# Altium schematic sheet NRF24L01.SchDoc(NRF24L01)
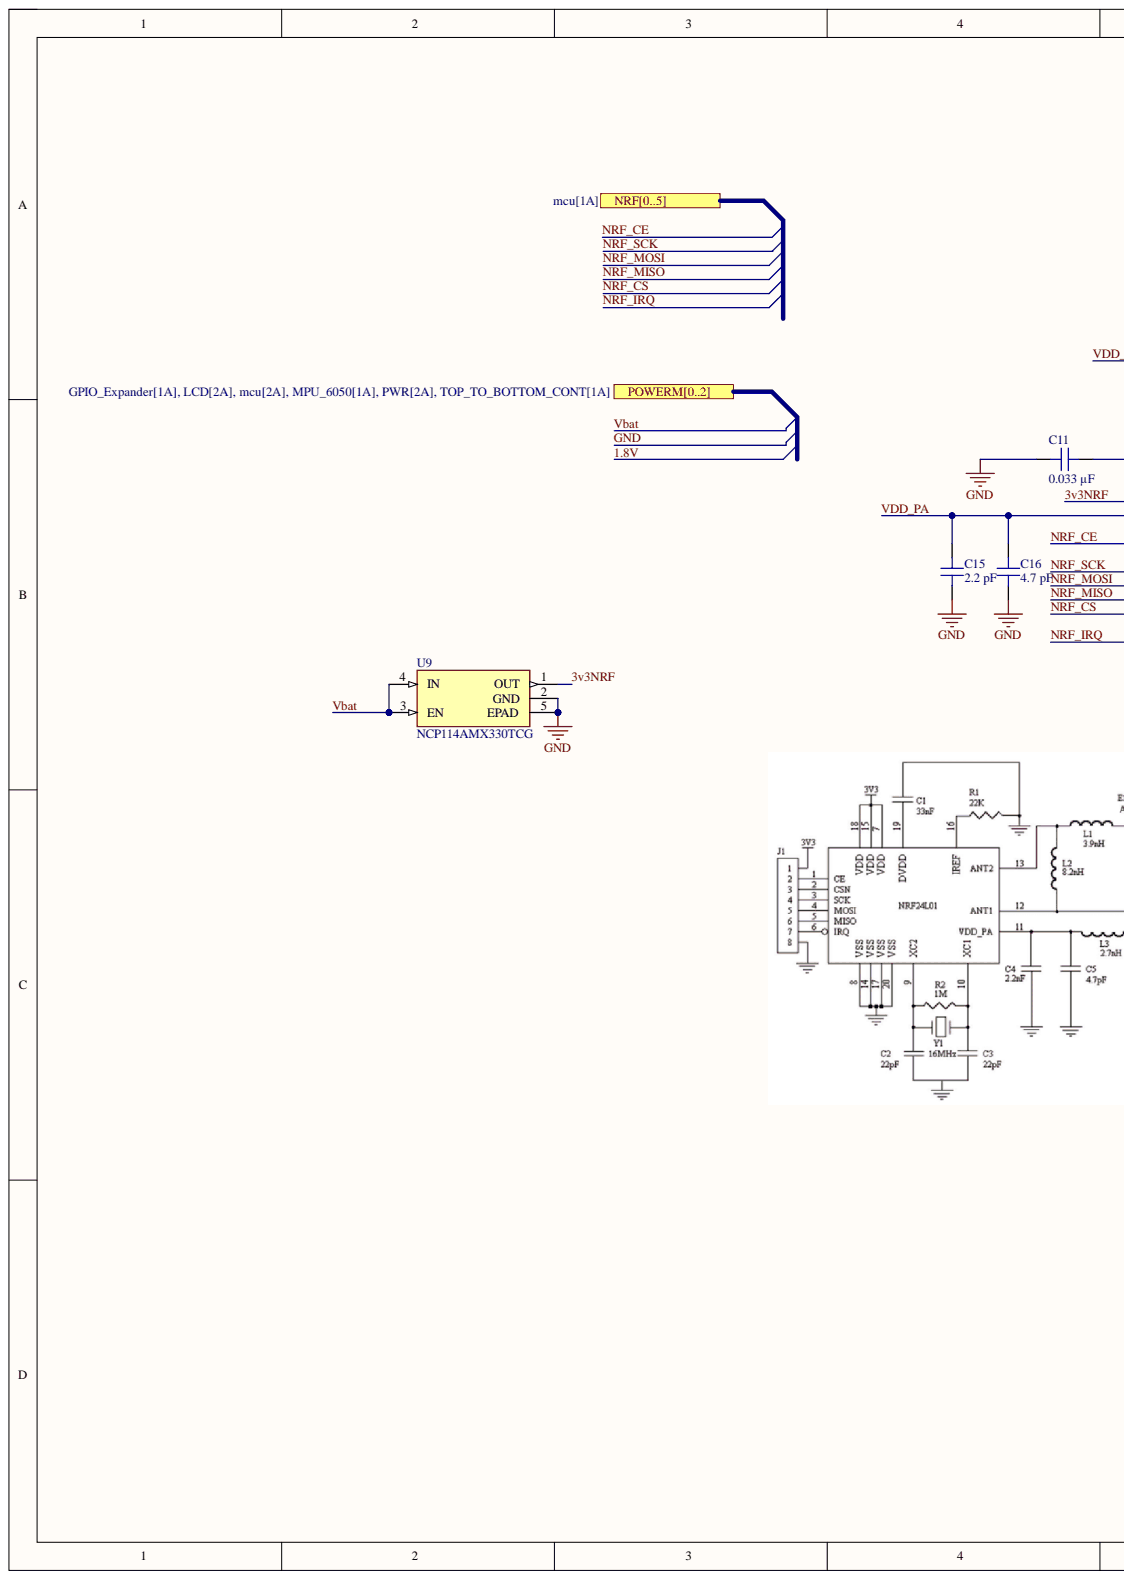
[diagram p0: NRF24L01.SchDoc, left]
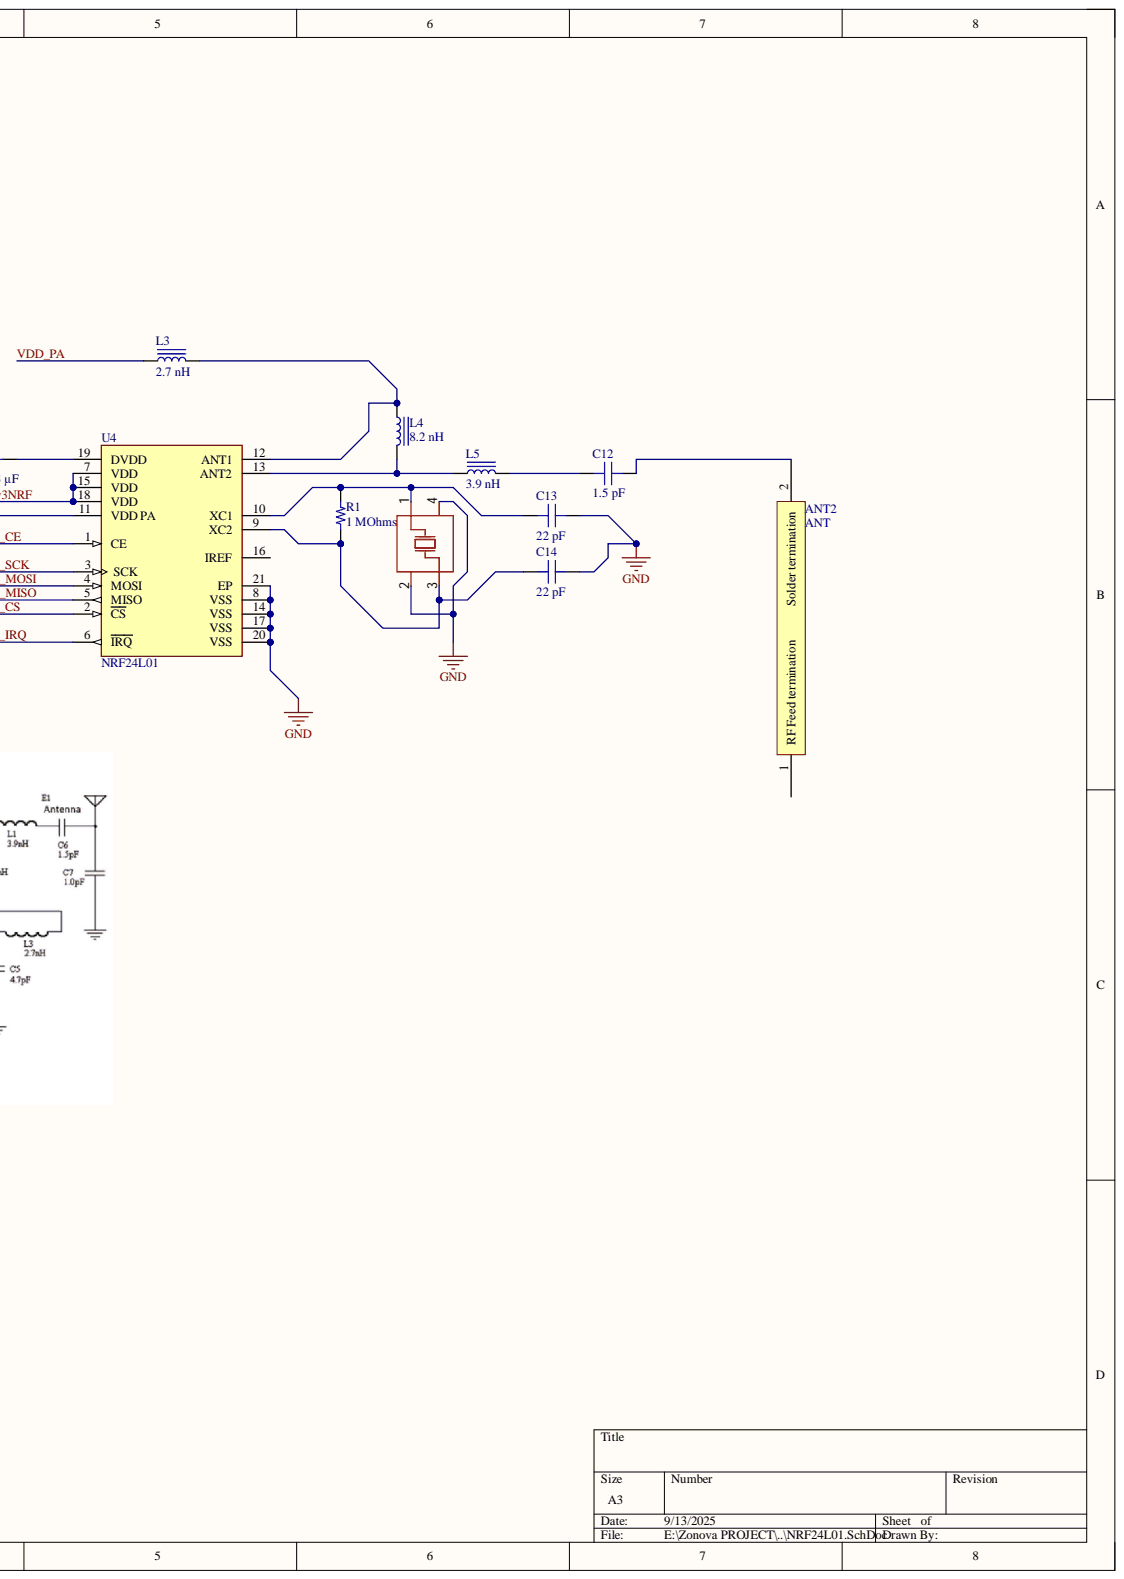
[diagram p1: NRF24L01.SchDoc, right]

## Parts
ANT2  ANT  [CAPC3216X13N]
C11  0.033 µF  [CAP 0402_1005]
C12  1.5 pF  [CAP 0402_1005]
C13  22 pF  [CAP 0402_1005]
C14  22 pF  [CAP 0402_1005]
C15  2.2 pF  [CAP 0402_1005]
C16  4.7 pF  [CAP 0402_1005]
L3  2.7 nH  [IND 0402_1005 RF WW]
L4  8.2 nH  [Murata LQW15 0402]
L5  3.9 nH  [Murata LQW15 0402]
R1  1 MOhms  [RES 0402_1005]
U4  NRF24L01  [FP-NRF24L01-IPC_C]
U9  NCP114AMX330TCG  [REG_NCP114AMX330TCG]
X2  16MHz  [CRYSTAL-SMD_4P-L3.2-W2.5-BL]  (value not drawn)

## Ports
NRF0, NRF1, NRF2, NRF3, NRF4, NRF5, NRF[0..5], POWERM0, POWERM1, POWERM2, POWERM[0..2]

## Nets
3v3NRF: U4.7(VDD) U4.15(VDD) U4.18(VDD) U9.1(OUT)
GND: C11.1 C13.2 C14.2 C15.1 C16.1 U4.8(VSS) U4.14(VSS) U4.17(VSS) U4.20(VSS) U4.21(EP) U9.2(GND) U9.5(EPAD) X2.2 X2.4
NRF_CE: U4.1(CE)
NRF_CS: U4.2(CS)
NRF_IRQ: U4.6(IRQ)
NRF_MISO: U4.5(MISO)
NRF_MOSI: U4.4(MOSI)
NRF_SCK: U4.3(SCK)
Vbat: U9.3(EN) U9.4(IN)
VDD_PA: C15.2 C16.2 L3.1 U4.11(VDD_PA)
NetANT2_2: ANT2.2 C12.2
NetC11_2: C11.2 U4.19(DVDD)
NetC12_1: C12.1 L5.2
NetC13_1: C13.1 R1.2 U4.10(XC1) X2.1
NetC14_1: C14.1 R1.1 U4.9(XC2) X2.3
NetL3_2: L3.2 L4.1 U4.12(ANT1)
NetL4_2: L4.2 L5.1 U4.13(ANT2)
1.8V:
N00005_0: ; port POWERM[0..2]
N00005_1: ; port POWERM[0..2]
N00005_2: ; port POWERM[0..2]
N00027_0: ; port NRF[0..5]
N00027_1: ; port NRF[0..5]
N00027_2: ; port NRF[0..5]
N00027_3: ; port NRF[0..5]
N00027_4: ; port NRF[0..5]
N00027_5: ; port NRF[0..5]

Not connected: ANT2 1; U4 16(IREF)

## Library data of the parts
C11 = 0402B333K100CT: CAP CER 0.033UF 10V X7R 0402; manufacturer: Walsin Technology Corporation; Database Table Name: Capacitors - Ceramic - 0402; Tolerance: ±10%; Applications: General Purpose; Capacitance: 0.033 µF; Component Kind: Standard; componentlink1description: Datasheet; componentlink1url: http://www.passivecomponent.com/wp-content/uploads/2018/10/MLCC.pdf; componentlink2description: DigiKey Link; componentlink2url: https://www.digikey.com/en/products/detail/walsin-technology-corporation/0402B333K100CT/9354700; Device Package: 0402 (1005 Metric); lastupdated: 2/9/2024 8:04:38 PM; Library Name: Celestial Altium Library - altium_library.DbLib; Lifecycle Status: Active; Minimum Order: 1; Mounting Type: Surface Mount, MLCC; Operating Temperature: -55°C ~ 125°C; Package / Case: 0402 (1005 Metric); packaging: CutTape; Part Number: 0402B333K100CT; Part Status: Active; partid: 1884; price: 0.01; series: -; Size / Dimension: 0.039" L x 0.020" W (1.00mm x 0.50mm); Supplier 1: DigiKey; Supplier Part Number 1: 1292-1202-1-ND; Temperature Coefficient: X7R; Thickness (Max): 0.022" (0.55mm); value: 0.033 µF; Voltage - Rated: 10V
C12 = 251R07S1R5AV4T: CAP CER 1.5PF 250V C0G/NP0 0402; manufacturer: Johanson Technology Inc.; Database Table Name: Capacitors - Ceramic - 0402; Temperature Coefficient: C0G, NP0; Tolerance: ±0.05pF; Applications: RF, Microwave, High Frequency; Capacitance: 1.5 pF; Component Kind: Standard; componentlink1description: Datasheet; componentlink1url: https://www.johansontechnology.com/downloads/catalog/johanson-technology-multi-layer-high-q-capacitors.pdf; componentlink2description: DigiKey Link; componentlink2url: https://www.digikey.com/en/products/detail/johanson-technology-inc/251R07S1R5AV4T/9658837; Device Package: 0402 (1005 Metric); Features: High Q, Low Loss, Ultra Low ESR; lastupdated: 2/9/2024 8:04:21 PM; Library Name: Celestial Altium Library - altium_library.DbLib; Lifecycle Status: Active; Minimum Order: 1; Mounting Type: Surface Mount, MLCC; Operating Temperature: -55°C ~ 125°C; Package / Case: 0402 (1005 Metric); packaging: TapeAndReel; Part Number: 251R07S1R5AV4T; Part Status: Active; partid: 12240; price: 0.05; series: S; Size / Dimension: 0.040" L x 0.020" W (1.02mm x 0.51mm); Supplier 1: DigiKey; Supplier Part Number 1: 712-1668-1-ND; Thickness (Max): 0.024" (0.61mm); value: 1.5 pF; Voltage - Rated: 250V
C13 C14 = 201R07S220GV4T: CAP CER 22PF 200V C0G/NP0 0402; manufacturer: Johanson Technology Inc.; Database Table Name: Capacitors - Ceramic - 0402; Temperature Coefficient: C0G, NP0; Tolerance: ±2%; Applications: RF, Microwave, High Frequency; Capacitance: 22 pF; Component Kind: Standard; componentlink1description: Datasheet; componentlink1url: https://www.johansontechnology.com/downloads/catalog/johanson-technology-multi-layer-high-q-capacitors.pdf; componentlink2description: DigiKey Link; componentlink2url: https://www.digikey.com/en/products/detail/johanson-technology-inc/201R07S220GV4T/9658867; Device Package: 0402 (1005 Metric); Features: High Q, Low Loss, Ultra Low ESR; lastupdated: 2/9/2024 8:04:18 PM; Library Name: Celestial Altium Library - altium_library.DbLib; Lifecycle Status: Active; Minimum Order: 1; Mounting Type: Surface Mount, MLCC; Operating Temperature: -55°C ~ 125°C; Package / Case: 0402 (1005 Metric); packaging: TapeAndReel; Part Number: 201R07S220GV4T; Part Status: Active; partid: 45669; price: 0.06; series: S; Size / Dimension: 0.040" L x 0.020" W (1.02mm x 0.51mm); Supplier 1: DigiKey; Supplier Part Number 1: 712-1698-1-ND; Thickness (Max): 0.024" (0.61mm); value: 22 pF; Voltage - Rated: 200V
C15 = 251R07S2R2AV4T: CAP CER 2.2PF 250V C0G/NP0 0402; manufacturer: Johanson Technology Inc.; Database Table Name: Capacitors - Ceramic - 0402; Temperature Coefficient: C0G, NP0; Tolerance: ±0.05pF; Applications: RF, Microwave, High Frequency; Capacitance: 2.2 pF; Component Kind: Standard; componentlink1description: Datasheet; componentlink1url: https://www.johansontechnology.com/downloads/catalog/johanson-technology-multi-layer-high-q-capacitors.pdf; componentlink2description: DigiKey Link; componentlink2url: https://www.digikey.com/en/products/detail/johanson-technology-inc/251R07S2R2AV4T/9658843; Device Package: 0402 (1005 Metric); Features: High Q, Low Loss, Ultra Low ESR; lastupdated: 2/9/2024 8:04:21 PM; Library Name: Celestial Altium Library - altium_library.DbLib; Lifecycle Status: Active; Minimum Order: 1; Mounting Type: Surface Mount, MLCC; Operating Temperature: -55°C ~ 125°C; Package / Case: 0402 (1005 Metric); packaging: TapeAndReel; Part Number: 251R07S2R2AV4T; Part Status: Active; partid: 12355; price: 0.15; series: S; Size / Dimension: 0.040" L x 0.020" W (1.02mm x 0.51mm); Supplier 1: DigiKey; Supplier Part Number 1: 712-1674-1-ND; Thickness (Max): 0.024" (0.61mm); value: 2.2 pF; Voltage - Rated: 250V
C16 = 201R07S4R7AV4T: CAP CER 4.7PF 200V C0G/NP0 0402; manufacturer: Johanson Technology Inc.; Database Table Name: Capacitors - Ceramic - 0402; Temperature Coefficient: C0G, NP0; Tolerance: ±0.05pF; Applications: RF, Microwave, High Frequency; Capacitance: 4.7 pF; Component Kind: Standard; componentlink1description: Datasheet; componentlink1url: https://www.johansontechnology.com/downloads/catalog/johanson-technology-multi-layer-high-q-capacitors.pdf; componentlink2description: DigiKey Link; componentlink2url: https://www.digikey.com/en/products/detail/johanson-technology-inc/201R07S4R7AV4T/9658851; Device Package: 0402 (1005 Metric); Features: High Q, Low Loss, Ultra Low ESR; lastupdated: 2/9/2024 8:04:23 PM; Library Name: Celestial Altium Library - altium_library.DbLib; Lifecycle Status: Active; Minimum Order: 1; Mounting Type: Surface Mount, MLCC; Operating Temperature: -55°C ~ 125°C; Package / Case: 0402 (1005 Metric); packaging: TapeAndReel; Part Number: 201R07S4R7AV4T; Part Status: Active; partid: 45674; price: 0.15; series: S; Size / Dimension: 0.040" L x 0.020" W (1.02mm x 0.51mm); Supplier 1: DigiKey; Supplier Part Number 1: 712-1682-1-ND; Thickness (Max): 0.024" (0.61mm); value: 4.7 pF; Voltage - Rated: 200V
L3 = 744765027A: FIXED IND 2.7NH 640MA 120MOHM SM; Manufacturer: Würth Elektronik; Database Table Name: Inductors - RF; DC Resistance (DCR): 120mOhm Max; Frequency - Self Resonant: 6GHz; Inductance Frequency - Test: 250 MHz; Material - Core: Ceramic; Q @ Freq: 16 @ 250MHz; Shielding: Unshielded; Tolerance: ±0.2nH; Type: Drum Core, Wirewound; Component Kind: Standard; ComponentLink1Description: Datasheet; ComponentLink1URL: https://katalog.we-online.de/pbs/datasheet/744765027A.pdf; ComponentLink2Description: DigiKey Link; ComponentLink2URL: https://www.digikey.com/en/products/detail/würth-elektronik/744765027A/1994078; Current Rating (Amps): 640 mA; Device Package: 0402 (1005 Metric); Height - Seated (Max): 0.024" (0.60mm); Inductance: 2.7 nH; LastUpdated: 6/3/2024 8:03:31 PM; Library Name: Celestial Altium Library - altium_library.DbLib; Lifecycle Status: Active; Minimum Order: 1; Mounting Type: Surface Mount; Operating Temperature: -40°C ~ 125°C; Package / Case: 0402 (1005 Metric); Packaging: TapeAndReel; Part Number: 744765027A; Part Status: Active; PartId: 680; Price: 0.27; Ratings: AEC-Q200; Series: WE-KI; Size / Dimension: 0.039" L x 0.022" W (1.00mm x 0.55mm); Supplier 1: DigiKey; Supplier Device Package: 0402 (1005 Metric); Supplier Part Number 1: 732-1708-1-ND; Value: 2.7 nH
L4 = LQW15AN8N2J8ZD: FIXED IND 8.2NH 1.5A 0.069OHM SM; Manufacturer: Murata Electronics; Database Table Name: Inductors - RF; DC Resistance (DCR): 69mOhm Max; Frequency - Self Resonant: 6.5GHz; Inductance Frequency - Test: 100 MHz; Material - Core: Non-Magnetic; Q @ Freq: 32 @ 250MHz; Shielding: Unshielded; Tolerance: ±5%; Type: Drum Core, Wirewound; Component Kind: Standard; ComponentLink1Description: Datasheet; ComponentLink1URL: https://search.murata.co.jp/Ceramy/image/img/P02/JELF243A-9138.pdf; ComponentLink2Description: DigiKey Link; ComponentLink2URL: https://www.digikey.com/en/products/detail/murata-electronics/LQW15AN8N2J8ZD/6799017; Current Rating (Amps): 1.5 A; Device Package: 0402 (1005 Metric); Height - Seated (Max): 0.024" (0.60mm); Inductance: 8.2 nH; LastUpdated: 7/19/2024 11:52:53 PM; Library Name: Celestial Altium Library - altium_library.DbLib; Lifecycle Status: Active; Minimum Order: 1; Mounting Type: Surface Mount; Operating Temperature: -55°C ~ 125°C; Package / Case: 0402 (1005 Metric); Packaging: TapeAndReel; Part Number: LQW15AN8N2J8ZD; Part Status: Active; PartId: 154; Price: 0.06; Ratings: AEC-Q200; Series: LQW15; Size / Dimension: 0.039" L x 0.024" W (1.00mm x 0.60mm); Supplier 1: DigiKey; Supplier Part Number 1: 490-15592-1-ND; Value: 8.2 nH
L5 = LQW15AN3N9D10D: FIXED IND 3.9NH 900MA 0.04OHM SM; Manufacturer: Murata Electronics; Database Table Name: Inductors - RF; DC Resistance (DCR): 40mOhm Max; Frequency - Self Resonant: 7GHz; Inductance Frequency - Test: 100 MHz; Material - Core: Non-Magnetic; Q @ Freq: 30 @ 250MHz; Shielding: Unshielded; Tolerance: ±0.5nH; Type: Drum Core, Wirewound; Component Kind: Standard; ComponentLink1Description: Datasheet; ComponentLink1URL: https://search.murata.co.jp/Ceramy/image/img/P02/JELF243A-0051.pdf; ComponentLink2Description: DigiKey Link; ComponentLink2URL: https://www.digikey.com/en/products/detail/murata-electronics/LQW15AN3N9D10D/2594912; Current Rating (Amps): 900 mA; Device Package: 0402 (1005 Metric); Height - Seated (Max): 0.024" (0.60mm); Inductance: 3.9 nH; LastUpdated: 7/19/2024 11:52:53 PM; Library Name: Celestial Altium Library - altium_library.DbLib; Lifecycle Status: Active; Minimum Order: 1; Mounting Type: Surface Mount; Operating Temperature: -55°C ~ 125°C; Package / Case: 0402 (1005 Metric); Packaging: TapeAndReel; Part Number: LQW15AN3N9D10D; Part Status: Active; PartId: 171; Price: 0.05; Series: LQW15; Size / Dimension: 0.039" L x 0.024" W (1.00mm x 0.60mm); Supplier 1: DigiKey; Supplier Part Number 1: 490-6815-1-ND; Value: 3.9 nH
R1 = MCS04020C1004FE000: RES SMD 1M OHM 1% 1/10W 0402; Manufacturer: Vishay Beyschlag; Database Table Name: Resistors - Surface Mount - 0402; Power (Watts): 0.1W, 1/10W; Temperature Coefficient: ±50ppm/°C; Component Kind: Standard; ComponentLink1Description: Datasheet; ComponentLink1URL: http://www.vishay.com/docs/28705/mcx0x0xpro.pdf; ComponentLink2Description: DigiKey Link; ComponentLink2URL: http://digikey.com/product-detail/en/vishay-beyschlag/MCS04020C1004FE000/MCS0402-1.00M-CFCT-ND/2607931; Composition: Thin Film; Device Package: 0402 (1005 Metric); Features: Anti-Sulfur; Height - Seated (Max): 0.015" (0.37mm); LastUpdated: 2/9/2024 8:30:21 PM; Library Name: Celestial Altium Library - altium_library.DbLib; Lifecycle Status: Active; Minimum Order: 1; Number of Terminations: 2; Operating Temperature: -55°C ~ 155°C; Package / Case: 0402 (1005 Metric); Packaging: TapeAndReel; Part Number: MCS04020C1004FE000; Part Status: Active; PartId: 3158; Price: 0.03; Resistance: 1 MOhms; Series: MCS - Professional; Size / Dimension: 0.039" L x 0.020" W (1.00mm x 0.50mm); Supplier 1: DigiKey; Supplier Device Package: 0402; Supplier Part Number 1: MCS0402-1.00M-CFCT-ND; Tolerance: ±1%; Value: 1 MOhms
U4 = CMP-228436-000003-1: IC RF TXRX ISM>1GHZ 20VFQFN; Component Kind: Standard; Library Name: Altium Content Vault
U9 = NCP114AMX330TCG: Linear Voltage Regulator IC Positive Fixed 1 Output 300mA 4-UDFN (1.0x1.0); MF: ON Semiconductor; Check_prices: https://www.snapeda.com/parts/NCP114AMX330TCG/Onsemi/view-part/?ref=eda; Component Kind: Standard; Library Name: NCP114AMX330TCG.IntLib; MP: NCP114AMX330TCG; Package: UDFN-4 ON Semiconductor; SnapEDA_Link: https://www.snapeda.com/parts/NCP114AMX330TCG/Onsemi/view-part/?ref=snap
X2 = ABM8G-16.000MHZ-18-D2Y-T: Manufacturer: ABRACON; Add into BOM: yes; Equivalent Series Resistance (ESR): 80Ω; Frequency Stability(Full temperature range): ±30ppm; Normal temperature Frequency Tolerance: ±20ppm; Component Kind: Standard; Convert to PCB: yes; Datasheet: https://atta.szlcsc.com/upload/public/pdf/source/20180906/C276421_0DB5B9EE345225C827799AFD2B19ACDB.pdf; Device: ABM8G-16.000MHZ-18-D2Y-T; JLCPCB Part Class: Extended Part; LCSC Part Name: 16MHz ±20ppm 18pF; Manufacturer Part: ABM8G-16.000MHZ-18-D2Y-T; PCB3D: CRYSTAL-SMD_4P-L3.2-W2.5-BL; Supplier: LCSC; Supplier Footprint: SMD3225-4P; Supplier Part: C1985423; Symbol: ABM8G-16.000MHZ-18-D2Y-T; Value: 16MHz
ANT2 = ANT: Component Kind: Standard; Library Name: ANT.IntLib
